# Altium schematic sheet HDC2022.SchDoc
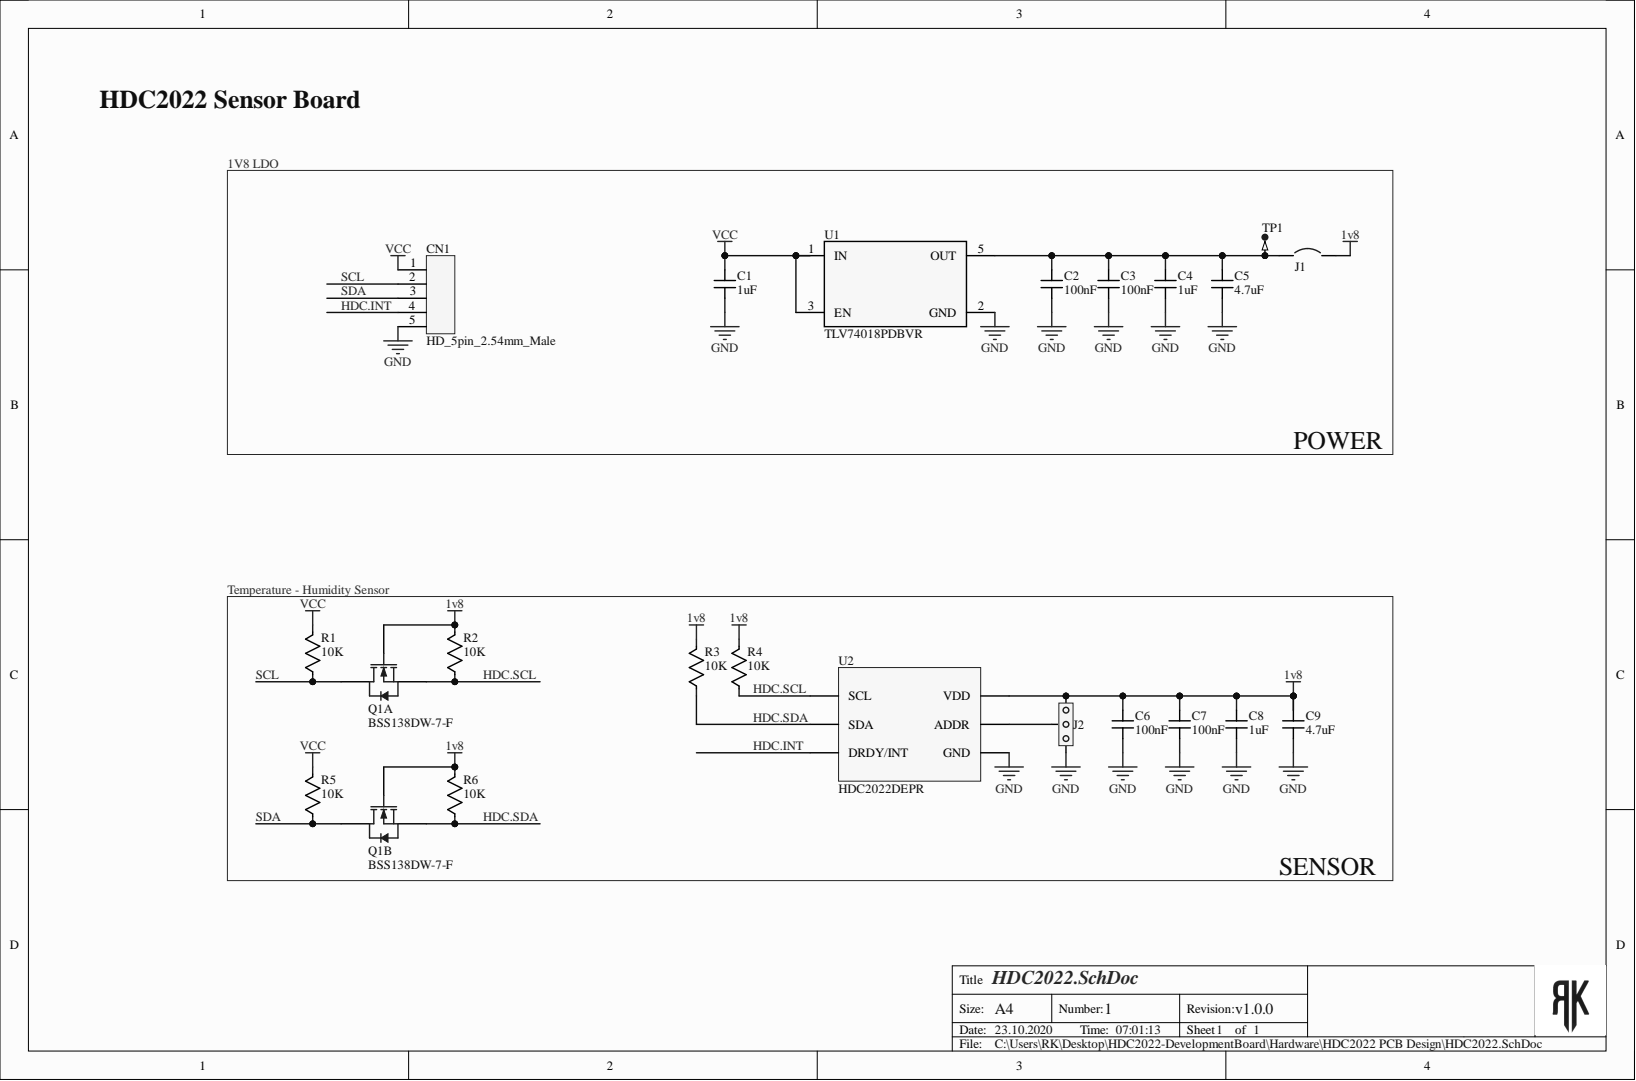
[diagram p0: HDC2022.SchDoc]

## Parts
C1  1uF  [CAP_0603]
C2  100nF  [CAP_0603]
C3  100nF  [CAP_0603]
C4  1uF  [CAP_0603]
C5  4.7uF  [CAP_0603]
C6  100nF  [CAP_0603]
C7  100nF  [CAP_0603]
C8  1uF  [CAP_0603]
C9  4.7uF  [CAP_0603]
CN1  HD_5pin_2.54mm_Male  [HD_5pin_2.54mm_male]
J1  Jumper  [SB_0603]  (value not drawn)  (no BOM)
J2  JUMPER_3Pin  [SB_0603 - 3 Pin]  (value not drawn)  (no BOM)
Q1  BSS138DW-7-F  [DIOD-SOT363-6_V]  (parts A,B)
R1  10K  [RES_0603]
R2  10K  [RES_0603]
R3  10K  [RES_0603]
R4  10K  [RES_0603]
R5  10K  [RES_0603]
R6  10K  [RES_0603]
TP1  Test Point  [TP]  (value not drawn)  (no BOM)
U1  TLV74018PDBVR  [SOIC_DBVR_TEX]
U2  HDC2022DEPR  [WSON-6]

## Nets
1v8: C6.2 C7.2 C8.2 C9.2 J1.2 J2.1 Q1.2 Q1.5 R2.2 R3.2 R4.2 R6.2 U2.5(VDD)
GND: C1.1 C2.1 C3.1 C4.1 C5.1 C6.1 C7.1 C8.1 C9.1 CN1.5 J2.3 U1.2(GND) U2.2(GND)
VCC: C1.2 CN1.1 R1.2 R5.2 U1.1(IN) U1.3(EN)
HDC.INT: CN1.4 U2.4(DRDY/INT)
HDC.SCL: Q1.1 R2.1 R4.1 U2.6(SCL)
HDC.SDA: Q1.4 R3.1 R6.1 U2.1(SDA)
SCL: CN1.2 Q1.6 R1.1
SDA: CN1.3 Q1.3 R5.1
NetC2_2: C2.2 C3.2 C4.2 C5.2 J1.1 TP1.1 U1.5(OUT)
NetJ2_2: J2.2 U2.3(ADDR)

Not connected: U1 4

## Library data of the parts
C1-C9 = Capacitor: Component Kind: Standard; Library Name: HDC2022.SchLib
Q1 = CMP-2000-05966-2: Dual N-Channel Enhancement Mode Field Effect Transistor, 50 V, 200 mA, -55 to 150 degC, 6-Pin SOT-363, RoHS, Tape and Reel; AccessRights: 2147483647; Brand: DiodesIncorporated; ContentType: Unified Component; Folder: Unified Components\Components\New Releases\New\; Number of Channels: 2Channel; Pd - Power Dissipation: 200mW; Rds On - Drain-Source Resistance: 3.5Ohms; RevisionId: 1; Transistor Type: 2N-Channel; Typical Turn-Off Delay Time: 20ns; Unit Weight: 0.000212oz; Vds - Drain-Source Breakdown Voltage: 50V; Vgs - Gate-Source Voltage: 20V; Id - Continuous Drain Current: 200mA; Channel Mode: Enhancement; Component Kind: Standard; Configuration: Dual; ContentTypeGUID: CB3C11C4-E317-11DF-B822-12313F0024A2; Datasheet URL: http://www.diodes.com/_files/datasheets/ds30203.pdf; Datasheet Version: Rev. 13-2, 01/2014; Factory Pack Quantity: 3000; FolderGUID: B7F4506A-9127-42E7-A087-46FE7B18D116; Height: 1mm; Length: 2.2mm; Library Name: HDC2022.SchLib; LifeCycle: Released; LifeCycleStateGUID: 61E382EE-6656-4F12-9E24-BE9327104A25; Manufacturer: DiodesIncorporated; Manufacturer URL: http://www.diodes.com/; Maximum Operating Temperature: +150C; Minimum Operating Temperature: -55C; Mounting Style: SMD/SMT; Mounting Technology: SurfaceMount; Package / Case: SOT-363-6; Package Description: 6-Pin Small Outline Transistor, Body 1.25 x 2 mm, Pitch 0.65 mm; Package Reference: SOT363-6; Packaging: Reel; Product: MOSFETSmallSignal; Product Category: MOSFET; ReleaseDate: 30/08/2017 08:55:44 AM; RoHS: True; Series: BSS138; Technology: Si; Transistor Polarity: N-Channel; Typical Turn-On Delay Time: 20ns; Width: 1.35mm; ItemGUID: D038B86C-7A34-4742-AE06-400EAE30903C; ItemHRID: CMP-2000-05966
R1-R6 = Resistor: Component Kind: Standard; Library Name: HDC2022.SchLib
U1 = TLV74018PDBVR: Manufacturer: Texas Instruments; Component Kind: Standard; Library Name: HDC2022.SchLib; Manufacturer Part Number: TLV74018PDBVR; Pricing 1: 1=0,24000, 10=0,19400, 25=0,16200, 100=0,09000, 250=0,07920, 500=0,06750, 1000=0,04590 (USD); RoHS: No; Stock 1: 4366; Supplier 1: Digi-Key; Supplier Part Number 1: 296-TLV74018PDBVRCT-ND
U2 = HDC2022DEPR: Manufacturer: Texas Instruments; Component Kind: Standard; Library Name: HDC2022.SchLib; Manufacturer Part Number: HDC2022DEPR; Pricing 1: 1=4,49000, 5=3,96000, 10=3,49800, 25=2,83800, 50=2,50800, 100=2,44200, 500=2,04600, 1000=1,91400 (USD); RoHS: No; Stock 1: 2745; Supplier 1: Digi-Key; Supplier Part Number 1: 296-HDC2022DEPRCT-ND
CN1 = HD_5pin_2.54mm_Male: Component Kind: Standard; Library Name: HDC2022.SchLib
J1 = Jumper: Description: Jumper; Library Name: HDC2022.SchLib; Published: 24-Mar-1999; Revision: July-2002: Re-released for DXP Platform.; Publisher: Altium Limited
J2 = JUMPER_3Pin: Library Name: HDC2022.SchLib
TP1 = Test Point: Library Name: HDC2022.SchLib
